# Altium schematic sheet 07_Power_Supply.SchDoc(07_Power_Supply)
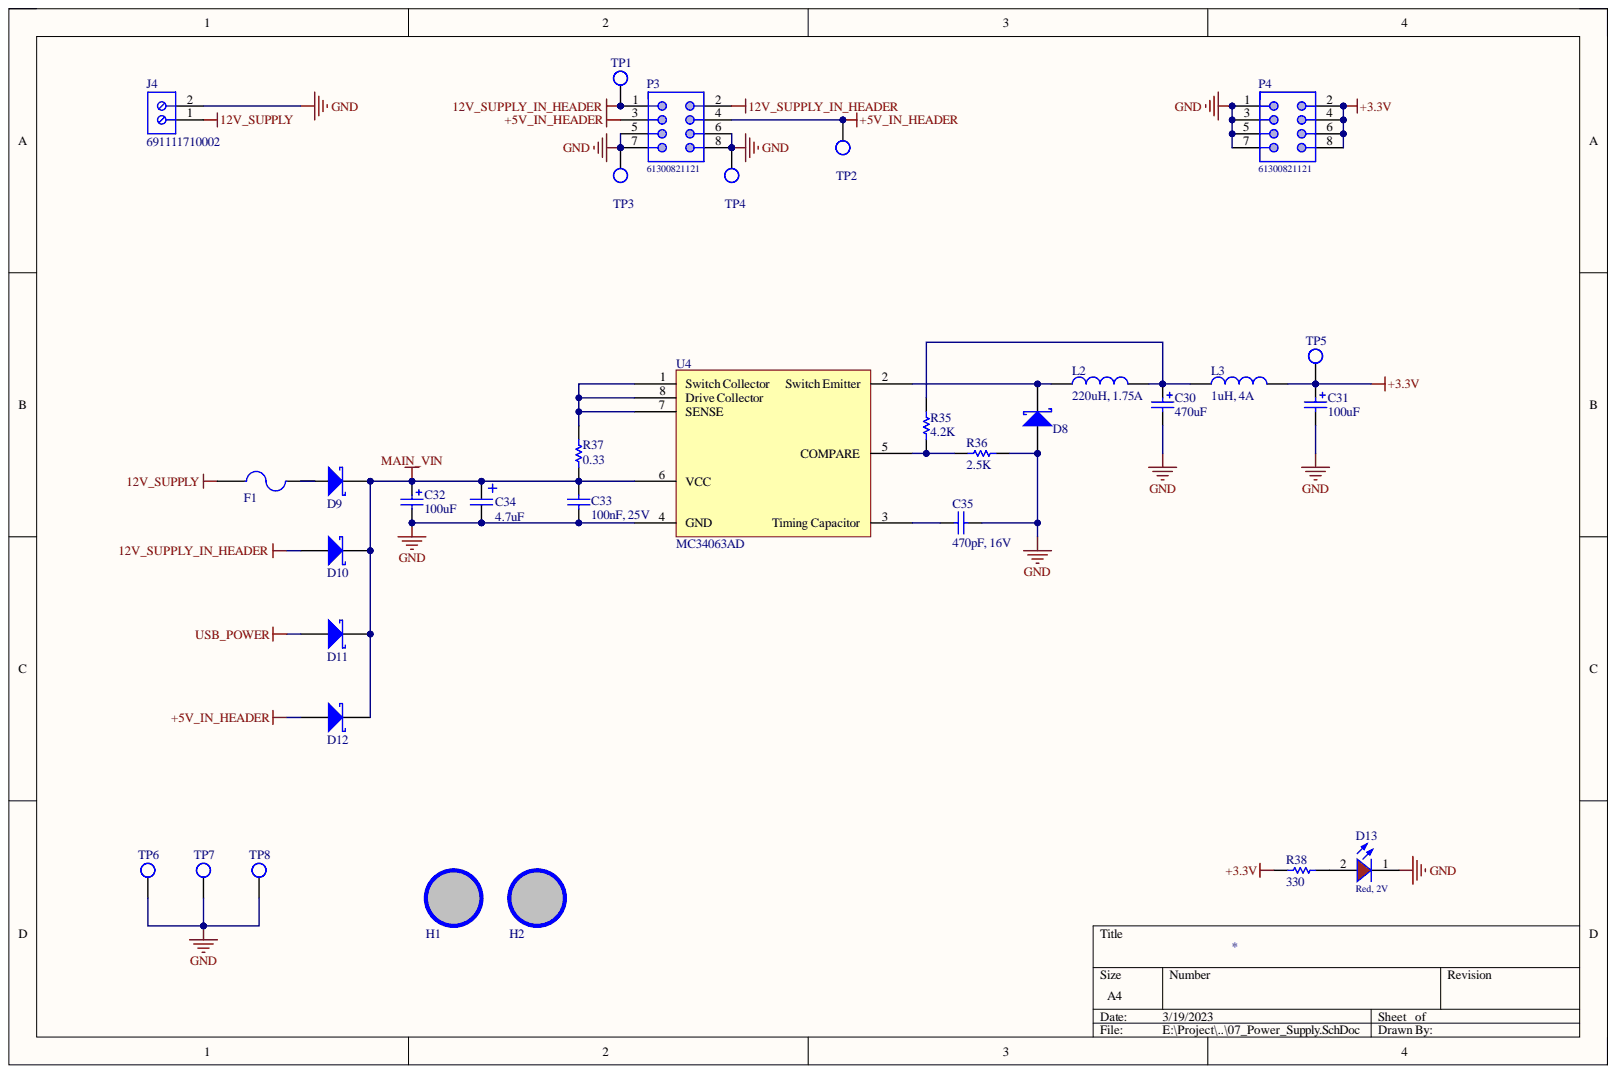
[diagram p0: 07_Power_Supply.SchDoc]

## Parts
C30  value 470uF  [CAP ALU SIZE F]  (hidden comment: Cap Pol SMD)
C31  value 100uF  [CAP ALU SIZE D]  (hidden comment: Cap Pol SMD)
C32  value 100uF  [CAP ALU SIZE D]  (hidden comment: Cap Pol SMD)
C33  100nF, 25V  [WCAP-CSGP_0603_H=0.8mm_Reflow]
C34  value 4.7uF  [CAPMP3216X18N]  (hidden comment: Tantalum Capacitor)
C35  470pF, 16V  [WCAP-CSGP_0603_H=0.8mm_Reflow]
D8  D Schottky  [SS34]  (value not drawn)
D9  D Schottky  [SS34]  (value not drawn)
D10  D Schottky  [SS34]  (value not drawn)
D11  D Schottky  [SS34]  (value not drawn)
D12  D Schottky  [SS34]  (value not drawn)
D13  Red, 2V  Forward Voltage typ.: 2V  [WL-SMCW_0603_H=0.7mm]
F1  Fuse  [FUSE SMD]  (value not drawn)
H1  Hole  [LO KHOAN 3MM]  (value not drawn)
H2  Hole  [LO KHOAN 3MM]  (value not drawn)
J4  691111710002  [691111710002]
L2  220uH, 1.75A  [WE-PD2A_5848]
L3  1uH, 4A  Rated Current: 4A  [WE-PD2A_4532]
P3  61300821121  [61300821121]
P4  61300821121  [61300821121]
R35  value 4.2K  [RES-SM-0603]  (hidden comment: RESISTOR SMD)
R36  value 2.5K  [RES-SM-0603]  (hidden comment: RESISTOR SMD)
R37  value 0.33  [RES-SM-0805]  (hidden comment: RESISTOR SMD)
R38  value 330  [RES-SM-0603]  (hidden comment: RESISTOR SMD)
TP1  Test point  [TP]  (value not drawn)
TP2  Test point  [TP]  (value not drawn)
TP3  Test point  [TP]  (value not drawn)
TP4  Test point  [TP]  (value not drawn)
TP5  Test point  [TP]  (value not drawn)
TP6  Test point  [TP]  (value not drawn)
TP7  Test point  [TP]  (value not drawn)
TP8  Test point  [TP]  (value not drawn)
U4  MC34063AD  [SOIC127P600X170-8N]

## Nets
12V_SUPPLY: F1.1 J4.1
12V_SUPPLY_IN_HEADER: D10.1 P3.1 P3.2 TP1.1
+3.3V: C31.1 L3.2 P4.2 P4.4 P4.6 P4.8 R38.2 TP5.1
+5V_IN_HEADER: D12.1 P3.3 P3.4 TP2.1
GND: C30.2 C31.2 C32.2 C33.1 C34.2 C35.2 D8.1 D13.1 J4.2 P3.5 P3.6 P3.7 P3.8 P4.1 P4.3 P4.5 P4.7 R36.1 TP3.1 TP4.1 TP6.1 TP7.1 TP8.1 U4.4(GND)
MAIN_VIN: C32.1 C33.2 C34.1 D9.2 D10.2 D11.2 D12.2 R37.1 U4.6(VCC)
USB_POWER: D11.1
NetC30_1: C30.1 L2.2 L3.1 R35.2
NetC35_1: C35.1 U4.3(Timing_Capacitor)
NetD8_2: D8.2 L2.1 U4.2(Switch_Emitter)
NetD9_1: D9.1 F1.2
NetD13_2: D13.2 R38.1
NetR35_1: R35.1 R36.2 U4.5(COMPARE)
NetR37_2: R37.2 U4.1(Switch_Collector) U4.7(SENSE) U4.8(Drive_Collector)

## Library data of the parts
C30-C32 = Cap Pol SMD: Polarized Capacitor (Radial); Component Kind: Standard; Library Name: PIF_Library.IntLib
C33 = 885012206071: WCAP-CSGP Multilayer Ceramic Chip Capacitor, General Purpose, size 0603, X7R, 100nF, 25V; Manufacturer: Wurth Elektronik; Capacitance Tolerance: +/-10%; Dissipation Factor: #NAME?; Isolation Resistance: >=5GOhm; Rated Voltage: 25VDC; Technical Reference: X7R0603104K025DFCT10000; Capacitance: 100nF; Ceramic Type: X7R; Component Kind: Standard; ComponentLink1Description: Manufacturer; ComponentLink1URL: http://www.we-online.de; ComponentLink2Description: Datasheet; ComponentLink2URL: https://www.we-online.com/catalog/datasheet/885012206071.pdf; Height: 0.8mm; Library Name: Altium_WCAP-CSGP (rev20a).IntLib; Manufacturer Part Number: 885012206071; Mounting Technology: SMT; Operating Temperature: -55 to +125degC; Packaging: Tape and Reel; Published Date: May 2020; Size: 0603
C35 = 885012006027: WCAP-CSGP Multilayer Ceramic Chip Capacitor, General Purpose, size 0603, NP0, 470pF, 16V; Manufacturer: Wurth Elektronik; Capacitance Tolerance: +/-5%; Dissipation Factor: Q>=1000; Isolation Resistance: >=10GOhm; Rated Voltage: 16VDC; Technical Reference: NP00603471J016DFCT10000; Capacitance: 470pF; Ceramic Type: NP0; Component Kind: Standard; ComponentLink1Description: Manufacturer; ComponentLink1URL: http://www.we-online.de; ComponentLink2Description: Datasheet; ComponentLink2URL: https://www.we-online.com/catalog/datasheet/885012006027.pdf; Height: 0.8mm; Library Name: Altium_WCAP-CSGP (rev20a).IntLib; Manufacturer Part Number: 885012006027; Mounting Technology: SMT; Operating Temperature: -55 to +125degC; Packaging: Tape and Reel; Published Date: May 2020; Size: 0603
D8-D12 = D Schottky: Schottky Diode; Code_JEDEC: DO-214-AA; Component Kind: Standard; LatestRevisionDate: 17-Jul-2002; LatestRevisionNote: Re-released for DXP Platform.; Library Name: PIF_Library.IntLib; PackageDescription: DO-214-AA/SMB; 2 C-Bend Leads; Body 5.3 x 3.6 mm, inc. leads (LxW); PackageReference: SMB; Published: 8-Jun-2000; Publisher: Altium Limited
D13 = 150060RS75000: WL-SMCW SMT Mono-color Chip LED Waterclear, size 0603, Red, 2V, 140deg; Chip Technology: AlInGaP; Dominant Wavelength typ.: 625nm; Luminous Intensity typ.: 250mcd; Peak Wavelength typ.: 630nm; Component Kind: Standard; ComponentLink1Description: Manufacturer; ComponentLink1URL: http://www.we-online.de; ComponentLink2Description: Datasheet; ComponentLink2URL: https://www.we-online.com/catalog/datasheet/150060RS75000.pdf; Emitting Color: Red; Library Name: Altium_WL-SMCW (rev20a).IntLib; Mounting Technology: SMT; Operating Temperature: -40 to +85degC; Packaging: Tape and Reel; Part Number: 150060RS75000; Published Date: December 2019; Size: 0603; Viewing Angle: 140deg; Publisher: Wurth Elektronik
H1 H2 = Hole: Hole 3mm; Component Kind: Standard; Library Name: PIF_Library.IntLib
J4 = 691111710002: WR-TBL Serie 111 Horizontal Entry Modular, Pressure Clamp, pitch 5mm, 2p; Compliance: Lead free / RoHS; Modularity: Yes; PCB/Cable/Panel: PCB; Pin Number: 2; Rated Current [UL]: 15A; Working Voltage [UL]: 250VAC; Application: Pressure Clamp; Component Kind: Standard; ComponentLink1Description: Manufacturer; ComponentLink1URL: http://www.we-online.de; ComponentLink2Description: Datasheet; ComponentLink2URL: https://katalog.we-online.de/em/datasheet/691111710002.pdf; Library Name: 691322310002..IntLib; Mounting Technology: THT; Operating Temperature: -40 to +105degC; Packaging: Box; Part Number: 691111710002; Pitch: 5mm; Published Date: October 2019; Type: Horizontal; Publisher: Wurth Elektronik
L2 = 784774222: WE-PD2A SMT Power Inductor, size 5848, 220uH, 0.41A; Manufacturer: Wurth Elektronik; DC Resistance max.: 1.57Ohm; DC Resistance typ.: 0.945Ohm; Rated Voltage: 80VDC; Saturation Current: 0.47A; Self Resonant Frequency: 5MHz; Component Kind: Standard; ComponentLink1Description: Manufacturer; ComponentLink1URL: http://www.we-online.de; ComponentLink2Description: Datasheet; ComponentLink2URL: https://www.we-online.com/catalog/datasheet/784774222.pdf; Inductance: 220uH; Library Name: Altium_WE-PD2A (rev20a).IntLib; Manufacturer Part Number: 784774222; Mounting Technology: SMT; Operating Temperature: -40 to +125degC; Packaging: Tape and Reel; Published Date: May 2020; Rated Current: 0.41A; Size: 5848
L3 = 7847730: WE-PD2A SMT Power Inductor, size 4532, 1uH, 4A; Manufacturer: Wurth Elektronik; DC Resistance max.: 0.049Ohm; DC Resistance typ.: 0.014Ohm; Rated Voltage: 80VDC; Saturation Current: 5.72A; Self Resonant Frequency: 110MHz; Component Kind: Standard; ComponentLink1Description: Manufacturer; ComponentLink1URL: http://www.we-online.de; ComponentLink2Description: Datasheet; ComponentLink2URL: https://www.we-online.com/catalog/datasheet/7847730.pdf; Inductance: 1uH; Library Name: Altium_WE-PD2A (rev20a).IntLib; Manufacturer Part Number: 7847730; Mounting Technology: SMT; Operating Temperature: -40 to +125degC; Packaging: Tape and Reel; Published Date: May 2020; Size: 4532
P3 P4 = 61300821121: WR-PHD Pin Header, THT, pitch 2.54mm, Dual Row, Vertical, 8p; Manufacturer: Wurth Elektronik; Contact Resistance: 20mOhm; Pin Number: 8; Rated Current: 3A; Withstanding Voltage: 500VAC; Working Voltage: 250VAC; Component Kind: Standard; ComponentLink1Description: Manufacturer; ComponentLink1URL: http://www.we-online.de; ComponentLink2Description: Datasheet; ComponentLink2URL: https://www.we-online.com/catalog/datasheet/61300821121.pdf; Gender: Pin Header; Library Name: Altium_WR-PHD (rev20a).IntLib; Manufacturer Part Number: 61300821121; Mounting Technology: THT; Operating Temperature: -40 to +105degC; Orientation: Vertical; Packaging: Bag; Pitch: 2.54mm; Published Date: May 2020; Row Number: Dual
R35-R38 = RESISTOR SMD: Resistor; Component Kind: Standard; Library Name: PIF_Library.IntLib
TP1-TP8 = TP: Component Kind: Standard; ComponentLink1Description: Supplier 1 Link; Library Name: MiclsLib.IntLib
U4 = MC34063: 1.5-A boost, buck, inverting switching regulator, operation from 0°C to 70°C; Manufacturer: Texas Instruments; Component Kind: Standard; ComponentLink1Description: Local Supplier Link; ComponentLink1URL: https://www.thegioiic.com/products/mc34063ad-ic-dieu-chinh-tang-giam-ap-1-5a-8-soic; Library Name: PowerSupply.SchLib; Local Supplier: Thegioiic; Local Supplier P/N: MC34063AD; Manufacturer P/N: MC34063AD
C34 = Tantalum Capacitor: Component Kind: Standard; Library Name: MiclsLib.IntLib
F1 = Fuse: Component Kind: Standard; Description: Fuse; Library Name: PIF_Library.IntLib
